# Altium schematic sheet DCDC_RPI.SchDoc(DCDC_RPI)
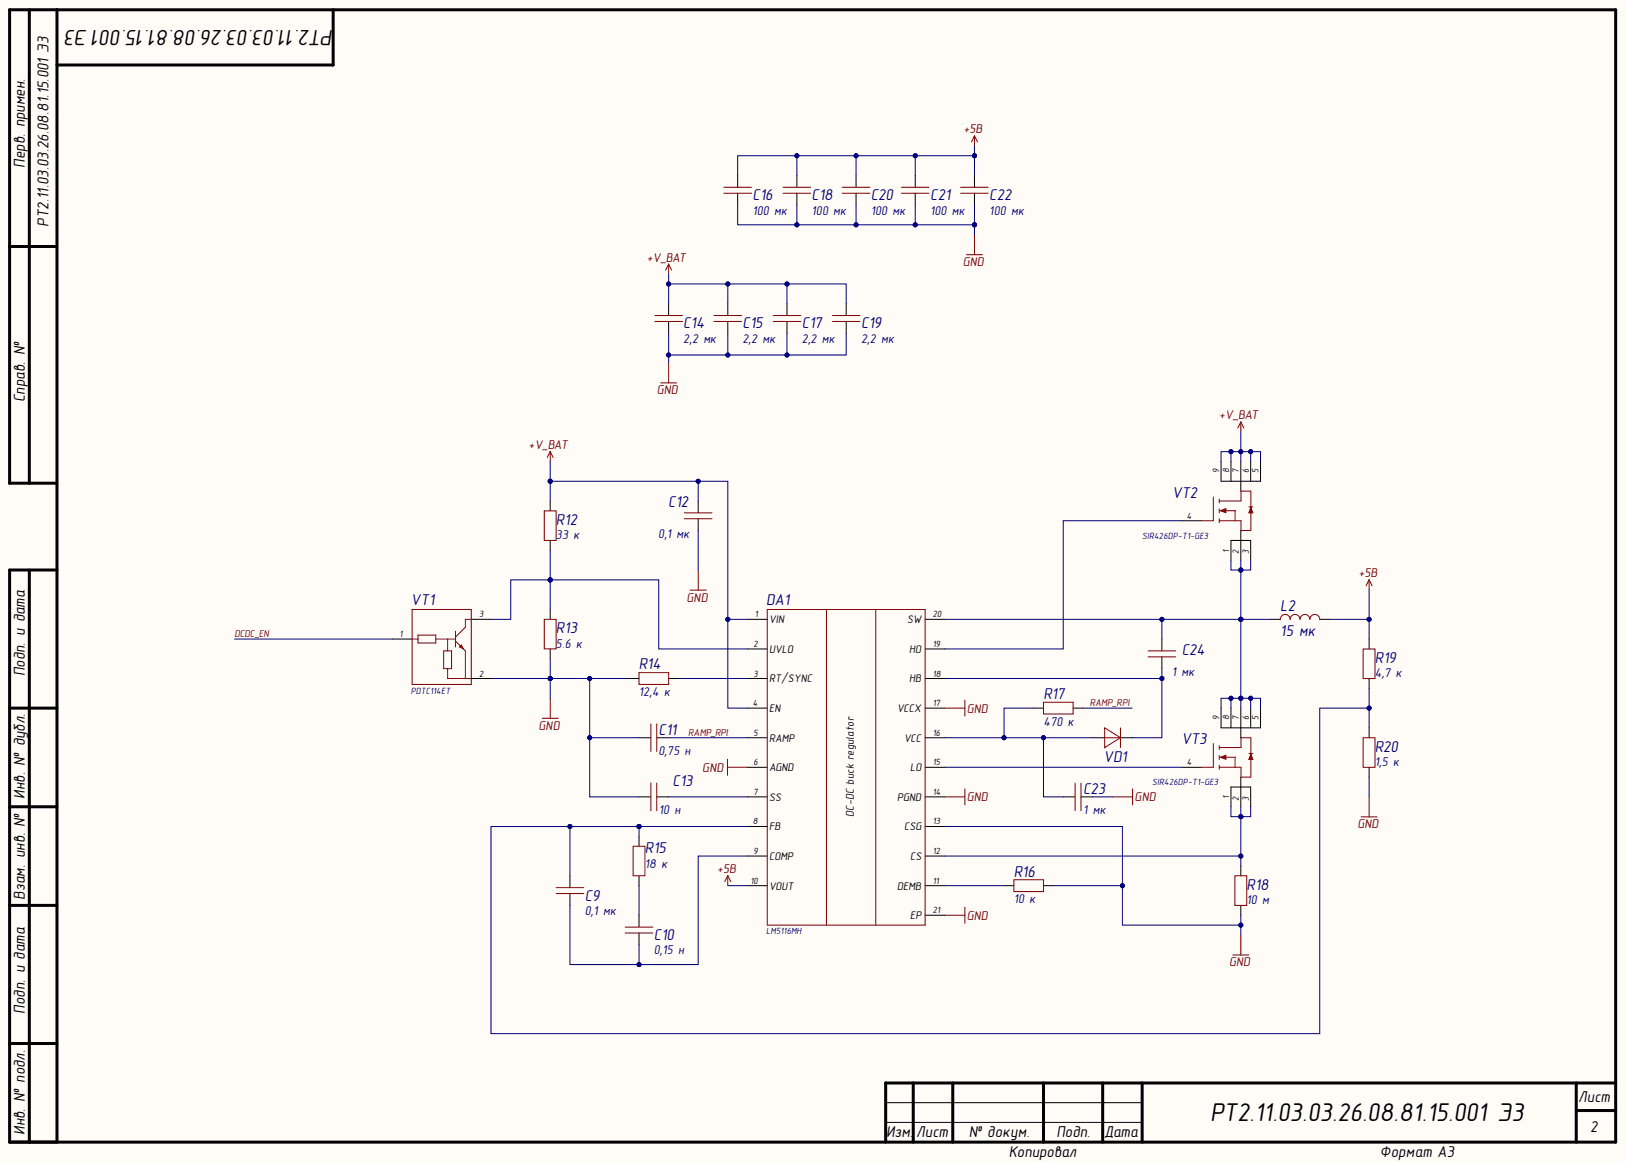
[diagram p0: DCDC_RPI.SchDoc]

## Parts
C9  value 0,1 мк  [0603C]  (hidden comment: Capacitor)
C10  value 0,15 н  Capacity (nF): 0,15  [0603C]  (hidden comment: Capacitor)
C11  value 0,75 н  Capacity (nF): 0,75  [0603C]  (hidden comment: Capacitor)
C12  value 0,1 мк  Tolerance: 0,1  [0603C]  (hidden comment: Capacitor)
C13  value 10 н  [0603C]  (hidden comment: Capacitor)
C14  value 2,2 мк  [1206C]  (hidden comment: Capacitor)
C15  value 2,2 мк  [1206C]  (hidden comment: Capacitor)
C16  CC1210MKX5R7BB107  [1210C]  (value not drawn)
C17  value 2,2 мк  [1206C]  (hidden comment: Capacitor)
C18  value 100 мк  [1210C]  (hidden comment: CC1210MKX5R7BB107)
C19  value 2,2 мк  [1206C]  (hidden comment: Capacitor)
C20  value 100 мк  [1210C]  (hidden comment: CC1210MKX5R7BB107)
C21  value 100 мк  [1210C]  (hidden comment: CC1210MKX5R7BB107)
C22  value 100 мк  [1210C]  (hidden comment: CC1210MKX5R7BB107)
C23  value 1 мк  [0603C]  (hidden comment: Capacitor)
C24  value 1 мк  [0603C]  (hidden comment: Capacitor)
DA1  LM5116MH  [HTSSOP-20]
L2  value 15 мк  [IHLP-4040]  (hidden comment: IHLP4040DZER150M11)
R12  value 33 к  [0603R]  (hidden comment: Resistor)
R13  value 5.6 к  [0603R]  (hidden comment: Resistor)
R14  value 12,4 к  [0603R]  (hidden comment: Resistor)
R15  value 18 к  [0603R]  (hidden comment: Resistor)
R16  value 10 к  [0603R]  (hidden comment: Resistor)
R17  value 470 к  [0603R]  (hidden comment: Resistor)
R18  value 10 м  [1210R]  (hidden comment: Resistor)
R19  value 4,7 к  [0603R]  (hidden comment: Resistor)
R20  value 1,5 к  [0603R]  (hidden comment: Resistor)
VD1  S1GFL  [SOD-123F]  (value not drawn)
VT1  PDTC114ET  [SOT23-3]
VT2  SIR426DP-T1-GE3  [PowerPAK_SO-8]
VT3  SIR426DP-T1-GE3  [PowerPAK_SO-8]

## Nets
+5В: C16.2 C18.2 C20.2 C21.2 C22.2 DA1.10(VOUT) L2.2 R19.2
+V_BAT: C12.2 C14.2 C15.2 C17.2 C19.2 DA1.1(VIN) DA1.4(EN) R12.2 VT2.5 VT2.6 VT2.7 VT2.8 VT2.9
GND: C11.1 C12.1 C13.1 C14.1 C15.1 C16.1 C17.1 C18.1 C19.1 C20.1 C21.1 C22.1 C23.2 DA1.6(AGND) DA1.13(CSG) DA1.14(PGND) DA1.17(VCCX) DA1.21(EP) R13.1 R14.1 R16.2 R18.1 R20.1 VT1.2
DCDC_EN: VT1.1
RAMP_RPI: C11.2 DA1.5(RAMP) R17.2
NetC9_1: C9.1 C10.1 DA1.9(COMP)
NetC9_2: C9.2 DA1.8(FB) R15.2 R19.1 R20.2
NetC10_2: C10.2 R15.1
NetC13_2: C13.2 DA1.7(SS)
NetC23_1: C23.1 DA1.16(VCC) R17.1 VD1.1
NetC24_1: C24.1 DA1.18(HB) VD1.2
NetC24_2: C24.2 DA1.20(SW) L2.1 VT2.1 VT2.2 VT2.3 VT3.5 VT3.6 VT3.7 VT3.8 VT3.9
NetDA1_2: DA1.2(UVLO) R12.1 R13.2 VT1.3
NetDA1_3: DA1.3(RT/SYNC) R14.2
NetDA1_11: DA1.11(DEMB) R16.1
NetDA1_12: DA1.12(CS) R18.2 VT3.1 VT3.2 VT3.3
NetDA1_15: DA1.15(LO) VT3.4
NetDA1_19: DA1.19(HO) VT2.4

## Library data of the parts
C9 = Capacitor_0,1u_10_50V_X7R_0603C: Конденсатор 0603C ёмкостью 0,1 мк 10%, 50 В, X7R; Capacity (nF): 100; Database Table Name: Capacitors_ceramic$; Value: 0,1 мк; Voltage (V): 50; Component Kind: Standard; Dielectric: X7R; Library Name: Hydrolib.DbLib; Part Number: Capacitor_0,1u_10_50V_X7R_0603C; Tolerance: 10%
C10 = Capacitor_0,15n_10_50V_X7R_0603C: Конденсатор; Database Table Name: Capacitors_ceramic$; Dielectric: X7R; Library Path2: Schematic\Capacitor.SchLib; Tolerance: 0,1; Voltage (V): 50; Component Kind: Standard; Library Name: Hydrolib.DbLib; Part Number: Capacitor_0,15n_10_50V_X7R_0603C
C11 = Capacitor_0,75n_10_50V_X7R_0603C: Конденсатор; Database Table Name: Capacitors_ceramic$; Dielectric: X7R; Library Path2: Schematic\Capacitor.SchLib; Tolerance: 0,1; Voltage (V): 50; Component Kind: Standard; Library Name: Hydrolib.DbLib; Part Number: Capacitor_0,75n_10_50V_X7R_0603C
C12 = Capacitor_0,1u_10_50V_X7R_0603C: Конденсатор; Capacity (nF): 100; Database Table Name: Capacitors_ceramic$; Dielectric: X7R; Library Path2: Schematic\Capacitor.SchLib; Voltage (V): 50; Component Kind: Standard; Library Name: Hydrolib.DbLib; Part Number: Capacitor_0,1u_10_50V_X7R_0603C
C13 = Capacitor_10n_10_50V_X7R_0603C: Конденсатор; Capacity (nF): 10; Database Table Name: Capacitors_ceramic$; Dielectric: X7R; Library Path2: Schematic\Capacitor.SchLib; Tolerance: 0,1; Voltage (V): 50; Component Kind: Standard; Library Name: Hydrolib.DbLib; Part Number: Capacitor_10n_10_50V_X7R_0603C
C14 = Capacitor_2,2u_10_50V_X7R_1206C: Конденсатор 1206C ёмкостью 2,2 мк 10%, 50 В, X7R; Capacity (nF): 2200; Database Table Name: Capacitors_ceramic$; Value: 2,2 мк; Voltage (V): 50; Component Kind: Standard; Dielectric: X7R; Library Name: Hydrolib.DbLib; Part Number: Capacitor_2,2u_10_50V_X7R_1206C; Tolerance: 10%
C15 C17 C19 = Capacitor_2,2u_10_50V_X7R_1206C: Конденсатор 1206C ёмкостью 2,2 мк 10%, 50 В, X7R; Capacity (nF): 2200; Database Table Name: Capacitors_ceramic$; Voltage (V): 50; Component Kind: Standard; Dielectric: X7R; Library Name: Hydrolib.DbLib; Part Number: Capacitor_2,2u_10_50V_X7R_1206C; Tolerance: 10%
C16 = CC1210MKX5R7BB107: Конденсатор 1210C ёмкостью 100 мк 20%, 16 В, X5R; Capacity (nF): 100000; Database Table Name: Capacitors_ceramic$; Value: 100 мк; Voltage (V): 16; Автор: rreali; Component Kind: Standard; Datasheet: https://cdn.promelec.ru/upload/datasheet/3/9/UPY-GPHC_X5R_4V-to-50V_25.pdf; Dielectric: X5R; Library Name: Hydrolib.DbLib; Part Number: CC1210MKX5R7BB107; Tolerance: 20%
C18 C20-C22 = CC1210MKX5R7BB107: Конденсатор 1210C ёмкостью 100 мк 20%, 16 В, X5R; Capacity (nF): 100000; Database Table Name: Capacitors_ceramic$; Voltage (V): 16; Автор: rreali; Component Kind: Standard; Datasheet: https://cdn.promelec.ru/upload/datasheet/3/9/UPY-GPHC_X5R_4V-to-50V_25.pdf; Dielectric: X5R; Library Name: Hydrolib.DbLib; Part Number: CC1210MKX5R7BB107; Tolerance: 20%
C23 C24 = Capacitor_1u_10_50V_X7R_0603C: Конденсатор; Capacity (nF): 1000; Database Table Name: Capacitors_ceramic$; Dielectric: X7R; Library Path2: Schematic\Capacitor.SchLib; Tolerance: 0,1; Voltage (V): 50; Component Kind: Standard; Library Name: Hydrolib.DbLib; Part Number: Capacitor_1u_10_50V_X7R_0603C
DA1 = LM5116MH: dc-dc понижающий adj 6-100v; Manufacturer_Part_Number: LM5116MH/NOPB; Database Table Name: Chips$; Component Kind: Standard; Library Name: Hydrolib.DbLib; Part Number: LM5116MH
L2 = IHLP4040DZER150M11: Катушка индуктивности SMD экранированная 15мкГн ±20% 6,25A 45мОм; Current: 6,25 А; Database Table Name: Inductance$; Inductance (μH): 15; Автор: rreali; Component Kind: Standard; Datasheet: cdn.promelec.ru/upload/datasheet/1/f/lp40dz11.pdf; Library Name: Hydrolib.DbLib; Part Number: IHLP4040DZER150M11
R12 = Resistor_33k_1_0603: Резистор номиналом 33 кОм и точностью 1%; Database Table Name: Resistors$; Component Kind: Standard; Library Name: Hydrolib.DbLib; Part Number: Resistor_33k_1_0603; Tolerance: 1%
R13 = Resistor_2.7k_1_0603: Резистор номиналом 2,7 кОм и точностью 1%; Database Table Name: Resistors$; Component Kind: Standard; Library Name: Hydrolib.DbLib; Part Number: Resistor_2.7k_1_0603; Tolerance: 1%
R14 = Resistor_12.4k_1_0603: Резистор номиналом 12,4 кОм и точностью 1%; Database Table Name: Resistors$; Component Kind: Standard; Library Name: Hydrolib.DbLib; Part Number: Resistor_12.4k_1_0603; Tolerance: 1%
R15 = Resistor_18k_1_0603: Резистор номиналом 18 кОм 1%; Database Table Name: Resistors$; Component Kind: Standard; Library Name: Hydrolib.DbLib; Part Number: Resistor_18k_1_0603; Tolerance: 1%
R16 = Resistor_10k_1_0603: Резистор номиналом 10 кОм и точностью 1%; Database Table Name: Resistors$; Component Kind: Standard; Library Name: Hydrolib.DbLib; Part Number: Resistor_10k_1_0603; Tolerance: 1%
R17 = Resistor_470k_1_0603: Резистор номиналом 470 кОм и точностью 1%; Database Table Name: Resistors$; Component Kind: Standard; Library Name: Hydrolib.DbLib; Part Number: Resistor_470k_1_0603; Tolerance: 1%
R18 = RL1210FR-070R01L: Резистор номиналом 10 мОм, точностью 1%, 0.5Вт; Database Table Name: Resistors$; Component Kind: Standard; Library Name: Hydrolib.DbLib; Part Number: RL1210FR-070R01L; Tolerance: 1%
R19 = Resistor_4.7k_1_0603: Резистор номиналом 4,7 кОм и точностью 1%; Database Table Name: Resistors$; Component Kind: Standard; Library Name: Hydrolib.DbLib; Part Number: Resistor_4.7k_1_0603; Tolerance: 1%
R20 = Resistor_1.5k_1_0603: Резистор номиналом 1,5 кОм и точностью 1%; Database Table Name: Resistors$; Component Kind: Standard; Library Name: Hydrolib.DbLib; Part Number: Resistor_1.5k_1_0603; Tolerance: 1%
VD1 = S1GFL: Диод 400В 1A [SOD-123F]; Current: 1 А; Database Table Name: Rectifier_diodes$; Voltage (V): 400; Автор: rreali; Component Kind: Standard; Datasheet: https://static.chipdip.ru/lib/868/DOC011868843.pdf; Library Name: Hydrolib.DbLib; Part Number: S1GFL
VT1 = PDTC114ET: цифровой npn 50в 100мА; Current (A): 0, 1 А; Database Table Name: Transistors$; Component Kind: Standard; Library Name: Hydrolib.DbLib; Part Number: PDTC114ET; Voltage (V): 50 В
VT2 VT3 = SIR426DP-T1-GE3: Транзистор полевой MOSFET N-канальный 40В 41.7Вт; Database Table Name: Transistors$; Component Kind: Standard; Library Name: Hydrolib.DbLib; Part Number: SIR426DP-T1-GE3; Напряжение С-И/К-Э: 40 В
